# Altium schematic sheet WiringDiagram.SchDoc
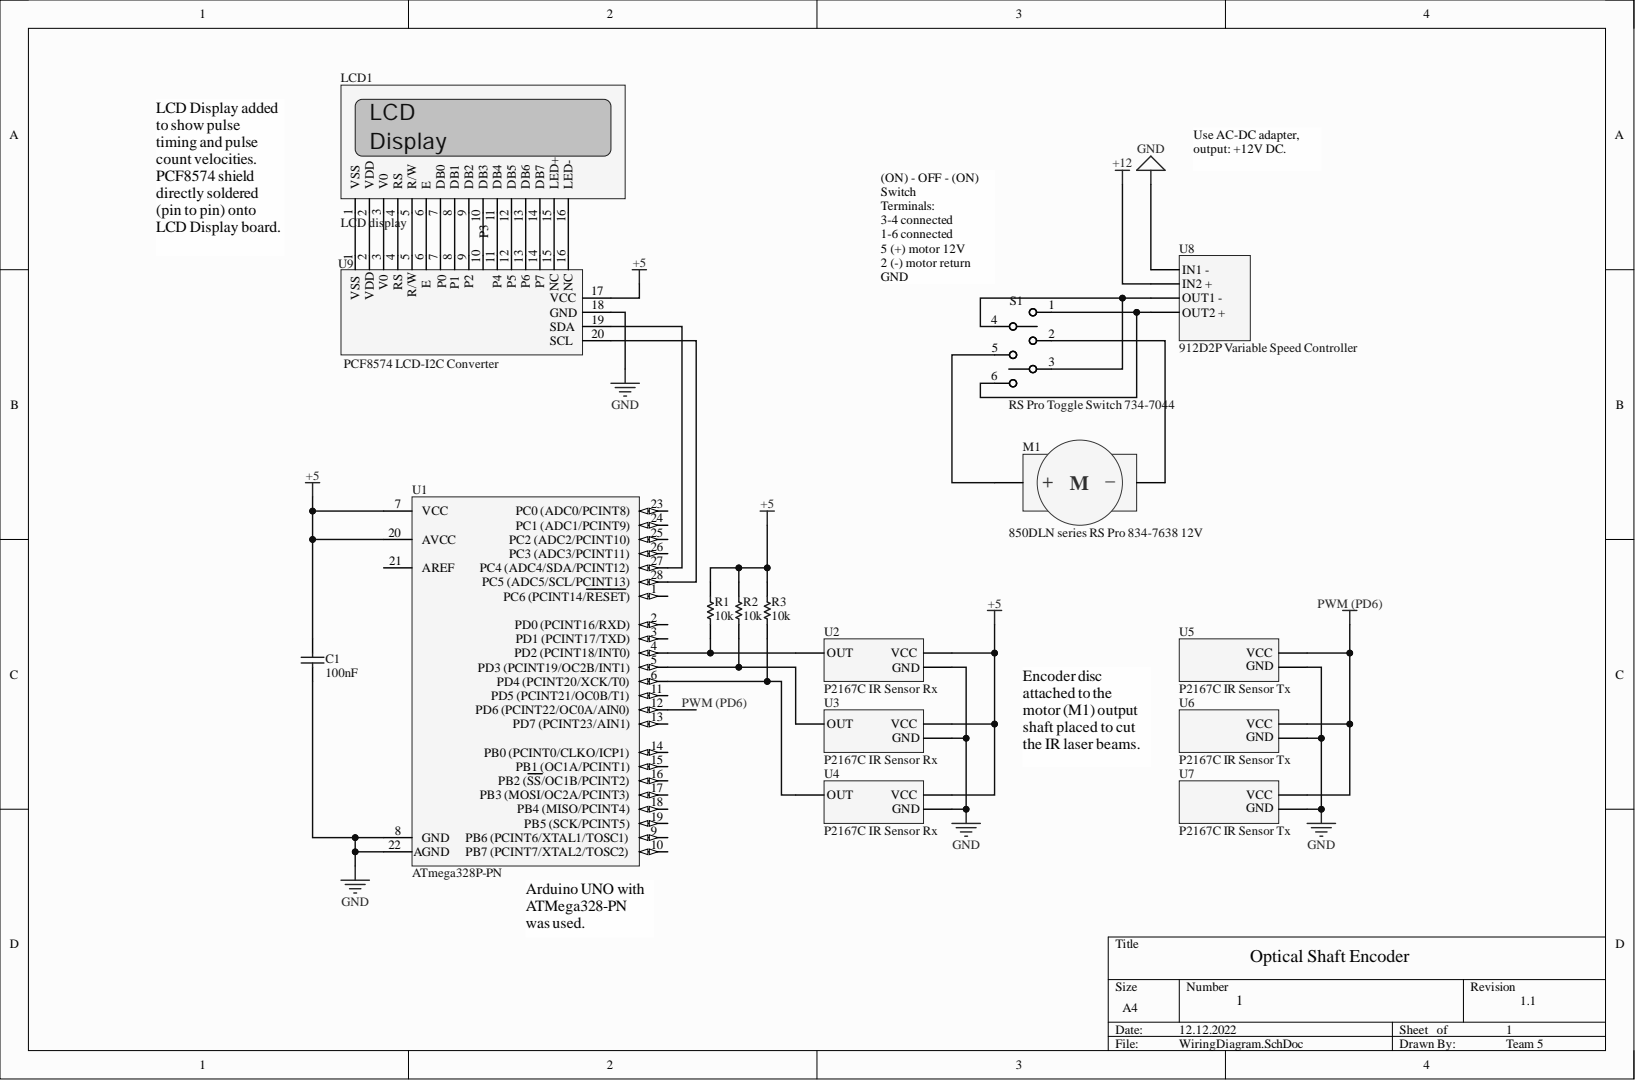
[diagram p0: WiringDiagram.SchDoc]

## Parts
C1  100nF  [CAPC1608X87X35NL15T15]
LCD1  LCD display  [Adafruit Industries 181-Footprint-1]
R1  10k  [PCB-gfkc8mq71fa2o4qnwuok-1]
R2  10k  [PCB-gfkc8mq71fa2o4qnwuok-1]
R3  10k  [PCB-gfkc8mq71fa2o4qnwuok-1]
S1  (no value)  [PCB-aal79x8hfdupp0vqb6he-1]
U1  ATmega328P-PN  [PCB-ri1b0ta5hr96dfqnvmqc-1]
  RS Pro Toggle Switch 734-7044  [PCB-aal79x8hfdupp0vqb6he-1]

## Nets
+5: C1.1 R1.1 R2.1 R3.1 U1.7(VCC) U1.20(AVCC)
GND: C1.2 U1.8(GND) U1.22(A_GND)
Net_3: S1.4 .3
Net_5: .5
Net_6: S1.1 .6
PWM (PD6): U1.12(PD6_(PCINT22/OC0A/AIN0))
NetR1_2: R1.2 U1.4(PD2_(PCINT18/INT0))
NetR2_2: R2.2 U1.5(PD3_(PCINT19/OC2B/INT1))
NetR3_2: R3.2 U1.6(PD4_(PCINT20/XCK/T0))
+12:

Not connected: LCD1 1(VSS) 2(VDD) 3(V0) 4(RS) 5(R/W) 6(E) 7(DB0) 8(DB1) 9(DB2) 10(DB3) 11(DB4) 12(DB5) 13(DB6) 14(DB7) 15(LED+) 16(LED-); S1 2; U1 1(PC6_(PCINT14/RESET)) 2(PD0_(PCINT16/RXD)) 3(PD1_(PCINT17/TXD)) 9 10 11(PD5_(PCINT21/OC0B/T1)) 13(PD7_(PCINT23/AIN1)) 14(PB0_(PCINT0/CLKO/ICP1)) 15(PB1_(OC1A/PCINT1)) 16(PB2_(SS/OC1B/PCINT2)) 17(PB3_(MOSI/OC2A/PCINT3)) 18(PB4_(MISO/PCINT4)) 19(PB5_(SCK/PCINT5)) 21(AREF) 23(PC0_(ADC0/PCINT8)) 24(PC1_(ADC1/PCINT9)) 25 26(PC3_(ADC3/PCINT11)) 27 28(PC5_(ADC5/SCL/PCINT13))

## Library data of the parts
C1 = CMP-151250-3: Multilayer Ceramic Capacitors MLCC - SMD/SMT 25volts 680pF 10%; Manufacturer Part Number: C0603S681K3RACTU; Capacitance: 6.8e-10 F; Dielectric Characteristic: X7R; Lead-Free Status: R; Voltage Rating (DC): 25.0 V; Capacitance Tolerance: ±10%; Case/Package: 0603; Component Kind: Standard; Dielectric Material: Ceramic; Library Name: My Personal Space; Mounting Style: Surface Mount; Octopart Url: https://octopart.com/c0603s681k3ractu-kemet-19050178; OctopartID: 90fc58d41b584f3b; RoHS: Compliant
LCD1 = CMP-612da9d07244beea-7: Conn 1/4" Mono Plug PL 2 POS Solder Lug ST Cable Mount 2 Terminal 1 Port; Manufacturer Part Number: 181; Cable Length: 31.0 mm; Gender: Male; Lead-Free Status: Lead Free; Number of Positions: 2; Color: Black; Component Kind: Standard; Contact Plating: Nickel; Library Name: My Personal Space; Material: Brass; Octopart URL: https://octopart.com/181-adafruit+industries-32978447; OctopartID: 612da9d07244beea; RoHS: Compliant; Size-Diameter: 11.1 mm; Size-Length: 14.2 mm
R1-R3 = CMP-610ca9363a79becb-36: Resistor; Carbon Film; Res 130 Ohms; Pwr-Rtg 0.25 W; Tol 5%; Axial; Bulk; resistance: 0.01 ohms; Component Kind: Standard; Library Name: My Personal Space
S1  = CMP-3e16f541920ee1d1-1: Toggle Switch, Dpdt, On-None-On, Panel, 5 A, 120 V Rohs Compliant: Yes; Manufacturer Part Number: 2-1825138-8; Actuator Type: Standard; Contact Current Rating: 5.00 A; Contact Resistance: 30.0 mΩ (max); Insulation Resistance: 1.00 GΩ; Lead-Free Status: Lead Free; Component Kind: Standard; Contact Material: Silver; Contact Plating: Silver; Contacts Type: DPDT; Current Rating: 5.00 A; ELV Compliant: Compliant; Flammability Rating: UL 94 V-0; Library Name: My Personal Space; Lifecycle Status: Active; Mounting Style: Solder; Octopart Url: https://octopart.com/2-1825138-8-te+connectivity-39684478; OctopartID: 3e16f541920ee1d1; Operating Temperature: 85.0 °C (max); Orientation: Vertical; Packaging: Bulk; REACH SVHC Compliance: No SVHC; RoHS: Compliant; Size-Height: 8.89 mm; Size-Length: 12.7 mm; Size-Width: 11.4 mm
U1 = CMP-2386807-6: 8-bit AVR Microcontroller, 32KB Flash, 1KB EEPROM, 2KB SRAM, 28-pin PDIP, Industrial Grade (-40°C to 105°C); Clock Speed: 20000000.0 Hz; RAM Memory Size: 2048 B; Component Kind: Standard; Library Name: My Personal Space; Manufacturer Part Number: ATMEGA328P-PN; Number of Pins: 28; Octopart Url: https://octopart.com/atmega328p-pn-atmel-18611746; OctopartID: c7540f451c101893; REACH SVHC Compliance: No SVHC; RoHS: Compliant
